# Altium schematic sheet Sensors.SchDoc
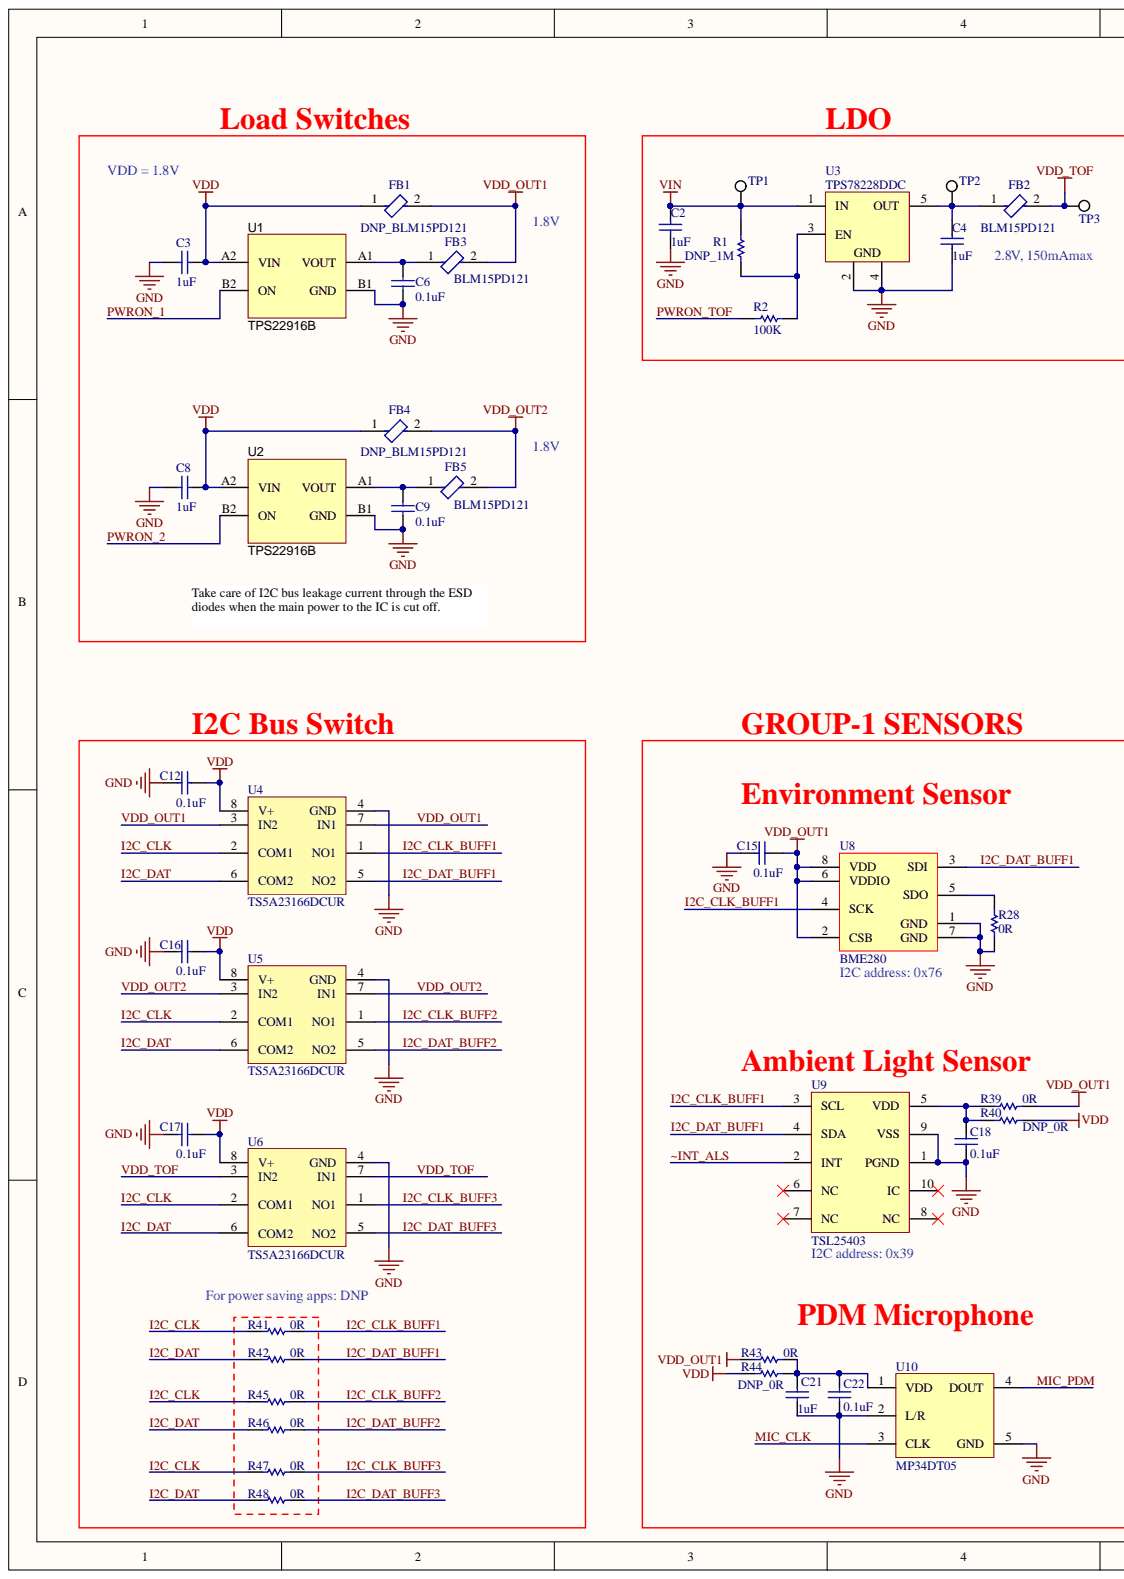
[diagram p0: Sensors.SchDoc, left]
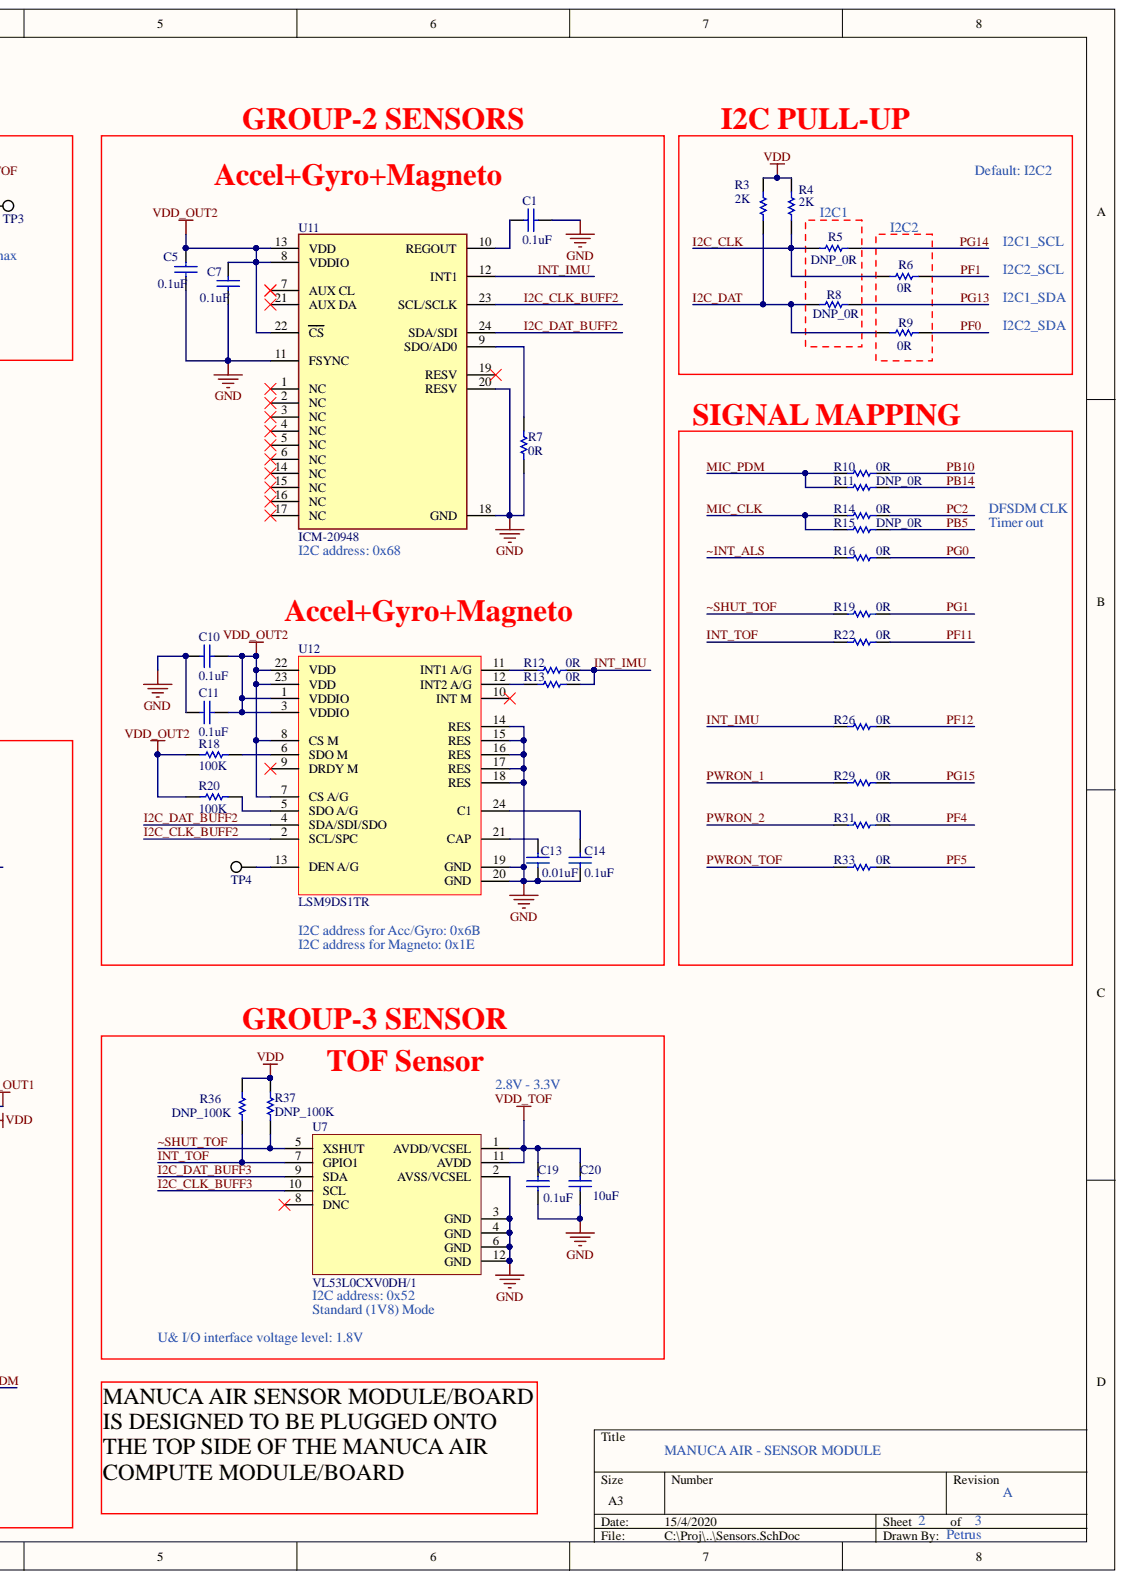
[diagram p1: Sensors.SchDoc, right]

## Parts
C1  0.1uF  [0402]
C2  1uF  [0603]
C3  1uF  [0402]
C4  1uF  [0603]
C5  0.1uF  [0402]
C6  0.1uF  [0402]
C7  0.1uF  [0402]
C8  1uF  [0402]
C9  0.1uF  [0402]
C10  0.1uF  [0402]
C11  0.1uF  [0402]
C12  0.1uF  [0402]
C13  0.01uF  [0402]
C14  0.1uF  [0402]
C15  0.1uF  [0402]
C16  0.1uF  [0402]
C17  0.1uF  [0402]
C18  0.1uF  [0402]
C19  0.1uF  [0402]
C20  10uF  [0805]
C21  1uF  [0402]
C22  0.1uF  [0402]
FB1  DNP_BLM15PD121  [0402]
FB2  BLM15PD121  [0402]
FB3  BLM15PD121  [0402]
FB4  DNP_BLM15PD121  [0402]
FB5  BLM15PD121  [0402]
R1  DNP_1M  [0402]
R2  100K  [0402]
R3  2K  [0402]
R4  2K  [0402]
R5  DNP_0R  [0402]
R6  0R  [0402]
R7  0R  [0402]
R8  DNP_0R  [0402]
R9  0R  [0402]
R10  0R  [0402]
R11  DNP_0R  [0402]
R12  0R  [0402]
R13  0R  [0402]
R14  0R  [0402]
R15  DNP_0R  [0402]
R16  0R  [0402]
R18  100K  [0402]
R19  0R  [0402]
R20  100K  [0402]
R22  0R  [0402]
R26  0R  [0402]
R28  0R  [0402]
R29  0R  [0402]
R31  0R  [0402]
R33  0R  [0402]
R36  DNP_100K  [0402]
R37  DNP_100K  [0402]
R39  0R  [0402]
R40  DNP_0R  [0402]
R41  0R  [0402]
R42  0R  [0402]
R43  0R  [0402]
R44  DNP_0R  [0402]
R45  0R  [0402]
R46  0R  [0402]
R47  0R  [0402]
R48  0R  [0402]
TP1  (no value)  [TP100]
TP2  (no value)  [TP100]
TP3  (no value)  [TP100]
TP4  (no value)  [TP100]
U1  TPS22916B  [BGA4C40P2X2_81X81X50]
U2  TPS22916B  [BGA4C40P2X2_81X81X50]
U3  TPS78228DDC  [SOT23-5]
U4  TS5A23166DCUR  [SOP50P310X200X90-8N]
U5  TS5A23166DCUR  [SOP50P310X200X90-8N]
U6  TS5A23166DCUR  [SOP50P310X200X90-8N]
U7  VL53L0CXV0DH/1  [VL53L0CXV0DH/1]
U8  BME280  [BME280]
U9  TSL25403  [TSL25403]
U10  MP34DT05  [MP34DT05]
U11  ICM-20948  [QFN24-3X3MM]
U12  LSM9DS1TR  [LSM9DS1]

## Nets
GND: C1.1 C2.1 C3.2 C4.1 C5.1 C6.2 C7.1 C8.2 C9.2 C10.1 C11.1 C12.1 C13.1 C14.1 C15.1 C16.1 C17.1 C18.1 C19.1 C20.2 C21.2 C22.1 R7.1 R28.1 U1.B1(GND) U2.B1(GND) U3.2 U3.4 U4.4(GND) U5.4(GND) U6.4(GND) U7.2(AVSS/VCSEL) U7.3(GND) U7.4(GND) U7.6(GND) U7.12(GND) U8.1(GND) U8.7(GND) U9.1(PGND) U9.9(VSS) U10.2(L/R) U10.5(GND) U11.11(FSYNC) U11.18(GND) U11.20(RESV) U12.14(RES) U12.15(RES) U12.16(RES) U12.17(RES) U12.18(RES) U12.19(GND) U12.20(GND)
VDD: C3.1 C8.1 C12.2 C16.2 C17.2 FB1.1 FB4.1 R3.1 R4.1 R36.1 R37.1 R40.1 R44.2 U1.A2(VIN) U2.A2(VIN) U4.8(V+) U5.8(V+) U6.8(V+)
VIN: C2.2 R1.1 TP1.1 U3.1(IN)
I2C_CLK: R4.2 R5.2 R6.2 R41.2 R45.2 R47.2 U4.2(COM1) U5.2(COM1) U6.2(COM1)
I2C_CLK_BUFF1: R41.1 U4.1(NO1) U8.4(SCK) U9.3(SCL)
I2C_CLK_BUFF2: R45.1 U5.1(NO1) U11.23(SCL/SCLK) U12.2(SCL/SPC)
I2C_CLK_BUFF3: R47.1 U6.1(NO1) U7.10(SCL)
I2C_DAT: R3.2 R8.2 R9.2 R42.2 R46.2 R48.2 U4.6(COM2) U5.6(COM2) U6.6(COM2)
I2C_DAT_BUFF1: R42.1 U4.5(NO2) U8.3(SDI) U9.4(SDA)
I2C_DAT_BUFF2: R46.1 U5.5(NO2) U11.24(SDA/SDI) U12.4(SDA/SDI/SDO)
I2C_DAT_BUFF3: R48.1 U6.5(NO2) U7.9(SDA)
INT_IMU: R12.1 R13.1 R26.2 U11.12(INT1)
INT_TOF: R22.2 R36.2 U7.7(GPIO1)
MIC_CLK: R14.2 R15.2 U10.3(CLK)
MIC_PDM: R10.2 R11.2 U10.4(DOUT)
PB5: R15.1
PB10: R10.1
PB14: R11.1
PC2: R14.1
PF0: R9.1
PF1: R6.1
PF4: R31.1
PF5: R33.1
PF11: R22.1
PF12: R26.1
PG0: R16.1
PG1: R19.1
PG13: R8.1
PG14: R5.1
PG15: R29.1
PWRON_1: R29.2 U1.B2(ON)
PWRON_2: R31.2 U2.B2(ON)
PWRON_TOF: R2.2 R33.2
VDD_OUT1: C15.2 FB1.2 FB3.2 R39.1 R43.2 U4.3(IN2) U4.7(IN1) U8.2(CSB) U8.6(VDDIO) U8.8(VDD)
VDD_OUT2: C5.2 C7.2 C10.2 C11.2 FB4.2 FB5.2 R18.2 R20.2 U5.3(IN2) U5.7(IN1) U11.8(VDDIO) U11.13(VDD) U11.22(CS) U12.1(VDDIO) U12.3(VDDIO) U12.7(CS_A/G) U12.8(CS_M) U12.22(VDD) U12.23(VDD)
VDD_TOF: C19.2 C20.1 FB2.2 TP3.1 U6.3(IN2) U6.7(IN1) U7.1(AVDD/VCSEL) U7.11(AVDD)
~INT_ALS: R16.2 U9.2(INT)
~SHUT_TOF: R19.2 R37.2 U7.5(XSHUT)
NetC1_2: C1.2 U11.10(REGOUT)
NetC4_2: C4.2 FB2.1 TP2.1 U3.5(OUT)
NetC6_1: C6.1 FB3.1 U1.A1(VOUT)
NetC9_1: C9.1 FB5.1 U2.A1(VOUT)
NetC13_2: C13.2 U12.21(CAP)
NetC14_2: C14.2 U12.24(C1)
NetC18_2: C18.2 R39.2 R40.2 U9.5(VDD)
NetC21_1: C21.1 C22.2 R43.1 R44.1 U10.1(VDD)
NetR1_2: R1.2 R2.1 U3.3(EN)
NetR7_2: R7.2 U11.9(SDO/AD0)
NetR12_2: R12.2 U12.11(INT1_A/G)
NetR13_2: R13.2 U12.12(INT2_A/G)
NetR18_1: R18.1 U12.6(SDO_M)
NetR20_1: R20.1 U12.5(SDO_A/G)
NetR28_2: R28.2 U8.5(SDO)
NetTP4_1: TP4.1 U12.13(DEN_A/G)

Not connected: U7 8(DNC); U9 6(NC) 7(NC) 8(NC) 10(IC); U11 1(NC) 2(NC) 3(NC) 4(NC) 5(NC) 6(NC) 7(AUX_CL) 14(NC) 15(NC) 16(NC) 17(NC) 19(RESV) 21(AUX_DA); U12 9(DRDY_M) 10(INT_M)

## Library data of the parts
C1 C5-C7 C9-C12 C14-C19 C22 = Capacitor_ST: Capacitor MLCC X7R 0.1uF 25V 0402; Manufacturer: Yageo; PartNumber: CC0402KRX7R8BB104; Component Kind: Standard; Signal Integrity: Cap; Simulation: CAP; Library Name: SensorPOD_R0.SCHLIB
C2 C4 = Capacitor_ST: Capacitor MLCC X7R 1uF 10V 0603; Manufacturer: Yageo; PartNumber: CC0603KRX7R6BB105; Component Kind: Standard; Signal Integrity: Cap; Simulation: CAP; Library Name: SensorPOD_R0.SCHLIB
C3 C8 C21 = Capacitor_ST: Capacitor MLCC X5R 1uF 6.3V 0402; Manufacturer: Samsung; PartNumber: CL05A105KQ5NNNC; Component Kind: Standard; Signal Integrity: Cap; Simulation: CAP; Library Name: SensorPOD_R0.SCHLIB
C13 = Capacitor_ST: Capacitor MLCC X7R 0.01uF 16V 0402; Manufacturer: Murata; PartNumber: GRM155R71C103MA01D; Component Kind: Standard; Signal Integrity: Cap; Simulation: CAP; Library Name: SensorPOD_R0.SCHLIB
C20 = Capacitor_ST: Capacitor MLCC X7R 10uF 10V 0805; Manufacturer: Murata; PartNumber: GRM21BR71A106KA73L; Component Kind: Standard; Signal Integrity: Cap; Simulation: CAP; Library Name: SensorPOD_R0.SCHLIB
FB1-FB5 = Ferrite Bead: Ferrite Beads Multi-Layer 120Ohm 25% 100MHz 1.3A 90mOhm DCR 0402; Manufacturer: Murata; PartNumber: BLM15PD121SN1D; Component Kind: Standard; ComponentLink1Description: Upverter; ComponentLink1URL: https://upverter.com/upn/revision/9e0a6ae32f230a59/; ComponentLink2Description: Datasheet; ComponentLink2URL: http://www.murata.com/en-eu/products/productdata/8796738650142/ENFA0003.pdf?1496719828000; Library Name: SensorPOD_R02.SCHLIB
R1 = Res1: Resistor Thick Film 0402 1M Ohm 5%; Manufacturer: KOA Speer; PartNumber: RK73B1ETTP105J; Component Kind: Standard; Library Name: Miscellaneous Devices.IntLib; Signal Integrity: Res; Simulation: RESISTOR
R2 R18 R20 R36 R37 = Res1: Resistor Thick Film 0402 100K Ohm 5%; Manufacturer: Samsung; PartNumber: RC1005J104CS; Component Kind: Standard; Library Name: Miscellaneous Devices.IntLib; Signal Integrity: Res; Simulation: RESISTOR
R3 R4 = Res1: Resistor Thick Film 0402 2K Ohm 5%; Manufacturer: KOA Speer; PartNumber: RK73B1ETTP202J; Component Kind: Standard; Library Name: SensorPOD_R0.SCHLIB
R5-R16 R19 R22 R26 R28 R29 R31 R33 R39-R48 = Res1: Resistor Thick Film 0402 0 ohm jumper; Manufacturer: KOA Speer; PartNumber: RK73Z1ETTP; Component Kind: Standard; Library Name: SensorPOD_R0.SCHLIB
TP1-TP4 = 5002: Test Point, Miniature, White, TH; Component Kind: Standard; ComponentLink1Description: Datasheet URL; ComponentLink1URL: http://www.keyelco.com/userAssets/file/M60-2p60.pdf
  TP1-TP3: Library Name: SensorPOD_RevC.SCHLIB
  TP4: Library Name: SensorPOD_RevB.SCHLIB
U1 U2 = TPS22916: Single Channel Ultra-Low Resistance Load Switch, 5.5V 2A 4-DSBGA package; Manufacturer: TI; PartNumber: TPS22916BYFPT; Component Kind: Standard; ComponentLink1Description: Datasheet Link; ComponentLink1URL: http://www.ti.com/lit/ds/symlink/tps22914b.pdf; Library Name: SensorPOD_RevC.SCHLIB
U3 = TPS783XX: LDO, 150 mA, Fixed 2.8V Output, 2.2 to 5.5 V Input, with Ultra-Low IQ, 5-pin SOT (DDC); Manufacturer: TI; PartNumber: TPS78228DDCT; Component Kind: Standard; ComponentLink1Description: Manufacturer URL; ComponentLink1URL: http://www.ti.com/; ComponentLink2Description: Datasheet; ComponentLink2URL: http://www.ti.com/general/docs/lit/getliterature.tsp?genericPartNumber=TPS78330&fileType=pdf; ComponentLink3Description: Package Specification; ComponentLink3URL: http://www.ti.com/litv/pdf/mpds123d; Library Name: SensorPOD_RevC.SCHLIB
U4-U6 = TS5A23166DCUR: Dual SPST Analog Switch 5-V/3.3-V 2-Channel 0.9 ohm 8-VSSOP; Manufacturer: TI; Component Kind: Standard; PartNumber: TS5A23166DCUR; Library Name: SensorPOD_RevC.SCHLIB
U7 = VL53L0CXV0DH/1: Time of Flight (TOF) laser ranging Sensor Module, I2C interface; Manufacturer: ST; Component Kind: Standard; PartNumber: VL53L0CXV0DH/1; Library Name: SensorPOD_RevC.SCHLIB
U8 = BME280: Humidity and Pressure Sensor 8-pin LGA; Manufacturer: Bosch Sensortec; Component Kind: Standard; PartNumber: BME280; Library Name: SensorPOD_RevC.SCHLIB
U9 = TSL2540: Digital Ambient Light Sensor with I2C interface; Manufacturer: AMS; Component Kind: Standard; PartNumber: TSL25403; Library Name: SensorPOD_RevC.SCHLIB
U10 = MP34DT05: MEMS Digital Microphone, Omnidirectional; Manufacturer: STM; PartNumber: MP34DT05TR-A; Component Kind: Standard; Library Name: SensorPOD_RevC.SCHLIB
U11 = ICM-20948: 9-Axis MEMS MotionTracking Device 24-pin QFN; Manufacturer: TDK InvenSense; Component Kind: Standard; PartNumber: ICM-20948; Library Name: SensorPOD_RevC.SCHLIB
U12 = LSM9DS1: 3D Liner Accelerometer, Gyroscope, Magnetometer I2C/SPI 24-pin LGA; Manufacturer: STMicro; Component Kind: Standard; ComponentLink1Description: Upverter; ComponentLink1URL: https://upverter.com/upn/revision/e4ce1d74d5f3d592/; ComponentLink2Description: Datasheet; ComponentLink2URL: http://www.st.com/st-web-ui/static/active/en/resource/technical/document/datasheet/DM00103319.pdf; Part Number: LSM9DS1TR; Library Name: SensorPOD_RevC.SCHLIB
